# Altium schematic sheet 103C8_baseboard.SchDoc(103C8_baseboard)
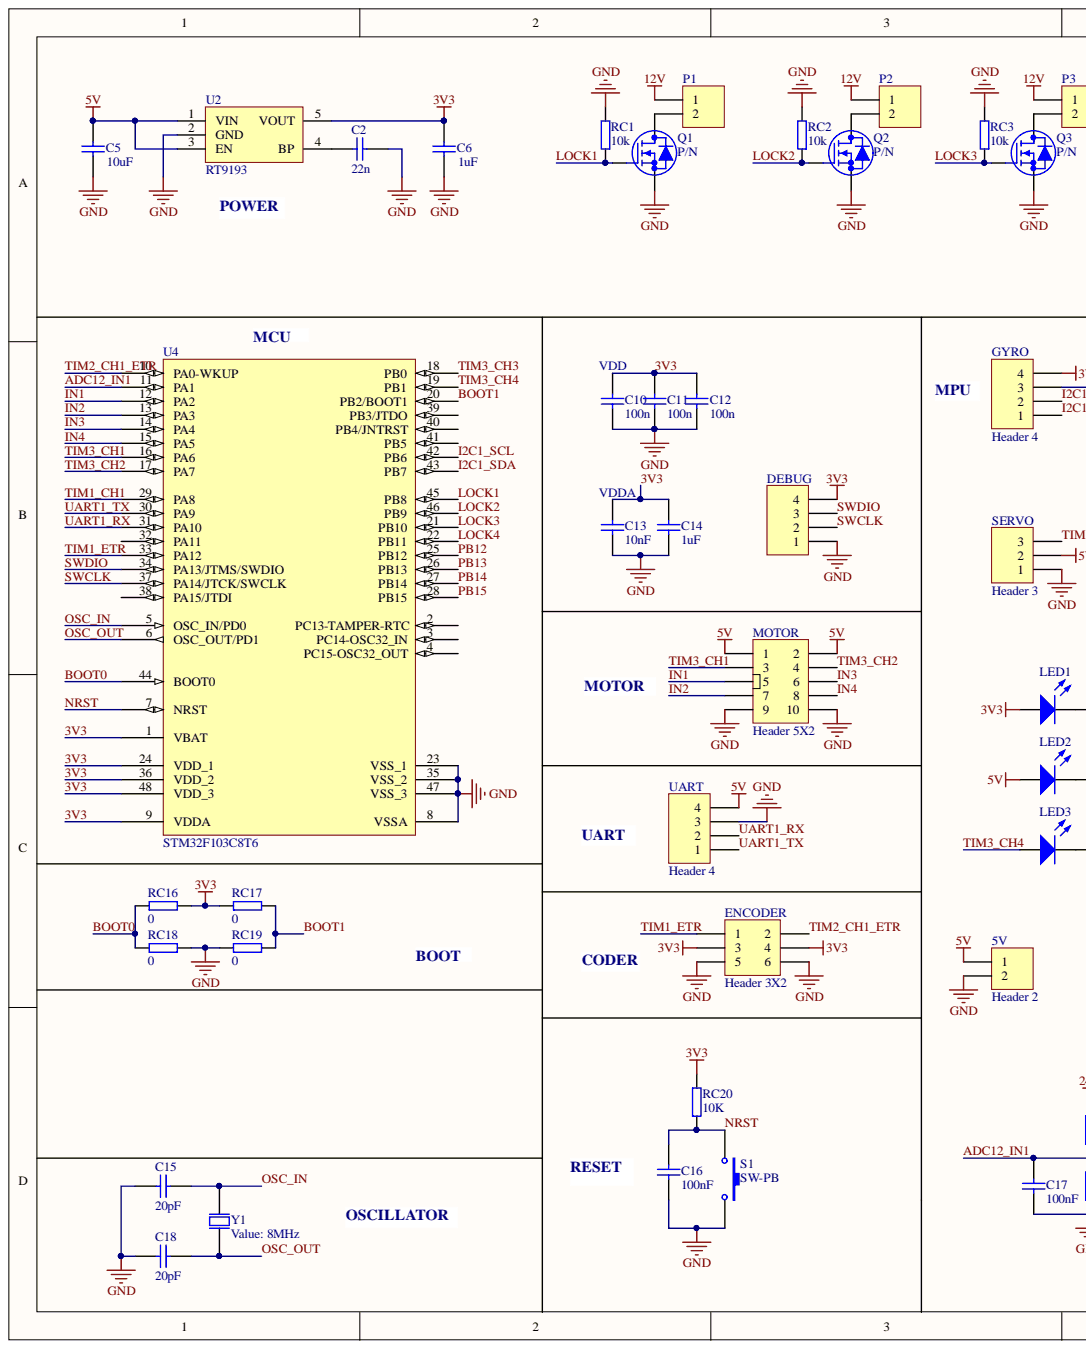
[diagram p0: 103C8_baseboard.SchDoc, left]
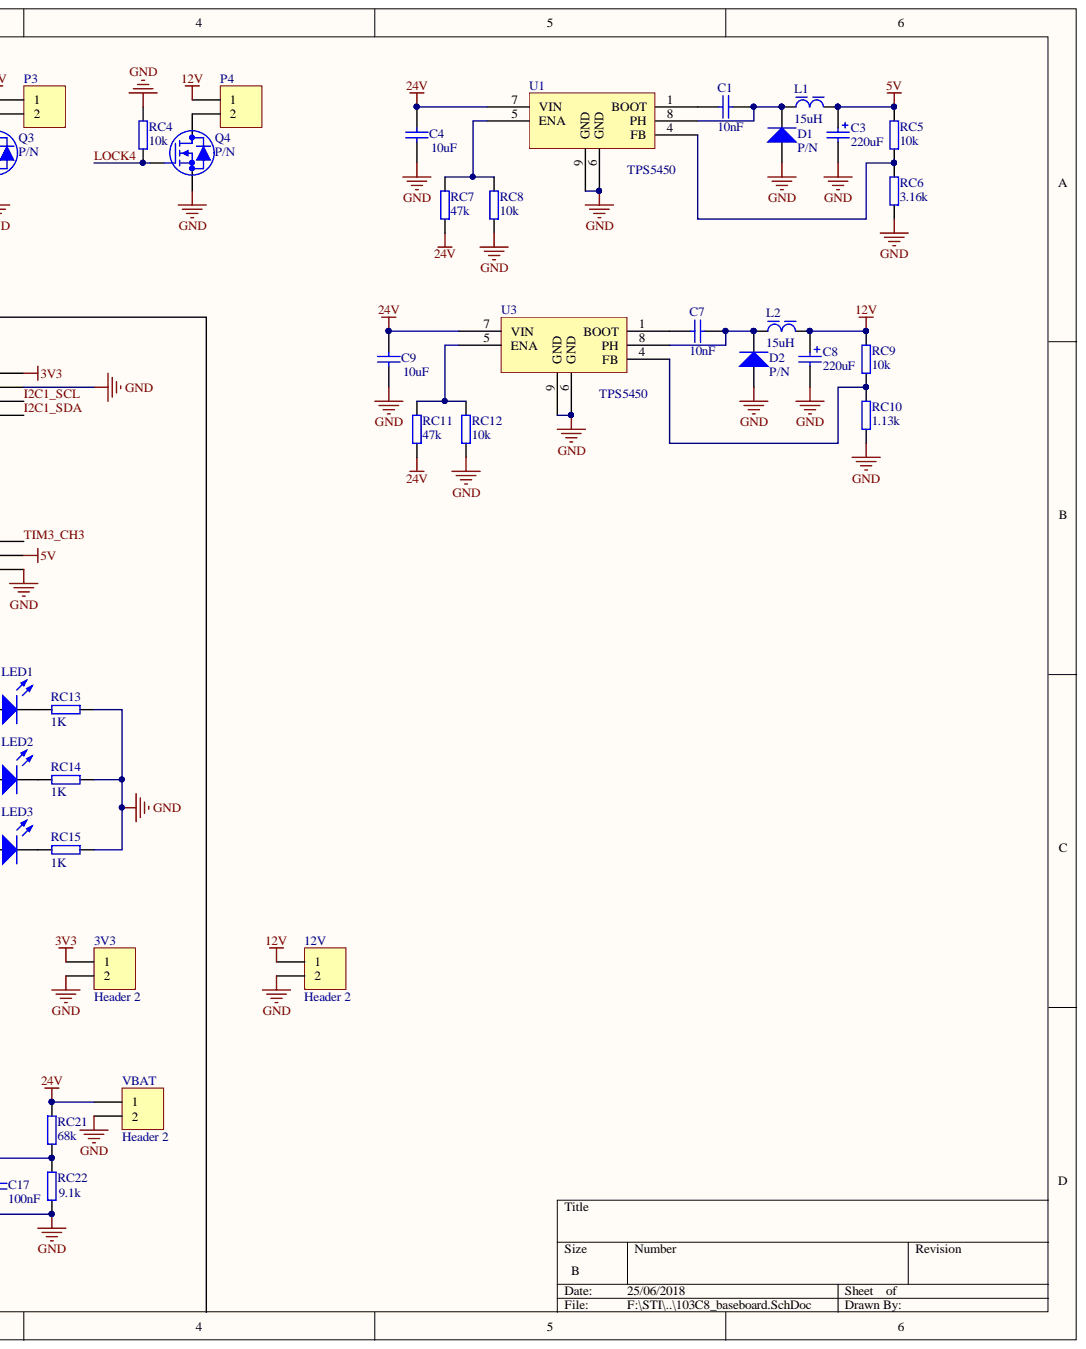
[diagram p1: 103C8_baseboard.SchDoc, right]

## Parts
3V3  Header 2  [HDR1X2]
5V  Header 2  [HDR1X2]
12V  Header 2  [HX2.54-2]
C1  10nF  [CAPC1608[0603]]
C2  22n  [CAPC1608[0603]]
C3  220uF  [CAPPR2-5X5]
C4  10uF  [CAPC1608[0603]]
C5  10uF  [CAPC1608[0603]]
C6  1uF  [CAPC1608[0603]]
C7  10nF  [CAPC1608[0603]]
C8  220uF  [CAPPR2-5X5]
C9  10uF  [CAPC1608[0603]]
C10  100n  [CAPC1608[0603]]
C11  100n  [CAPC1608[0603]]
C12  100n  [CAPC1608[0603]]
C13  10nF  [CAPC1608[0603]]
C14  1uF  [CAPC1608[0603]]
C15  20pF  [CAPC1608[0603]]
C16  100nF  [CAPC1608[0603]]
C17  100nF  [CAPC1608[0603]]
C18  20pF  [CAPC1608[0603]]
D1  P/N  [SMC[DO-214AB]]
D2  P/N  [SMC[DO-214AB]]
DEBUG  (no value)  [HDR1X4]
ENCODER  Header 3X2  [HDR2X3]
GYRO  Header 4  [HDR1X4]
L1  15uH  [SWPA8040S]
L2  15uH  [SWPA8040S]
LED1  Red  [LEDC1608(0603)]  (value not drawn)
LED2  Red  [LEDC1608(0603)]  (value not drawn)
LED3  Red  [LEDC1608(0603)]  (value not drawn)
MOTOR  Header 5X2  [HDR2X5]
P1  Header 2  [HX2.54-2]  (value not drawn)
P2  Header 2  [HX2.54-2]  (value not drawn)
P3  Header 2  [HX2.54-2]  (value not drawn)
P4  Header 2  [HX2.54-2]  (value not drawn)
Q1  P/N  [SOT23]
Q2  P/N  [SOT23]
Q3  P/N  [SOT23]
Q4  P/N  [SOT23]
RC1  10k  [RESC1608[0603]]
RC2  10k  [RESC1608[0603]]
RC3  10k  [RESC1608[0603]]
RC4  10k  [RESC1608[0603]]
RC5  10k  [RESC1608[0603]]
RC6  3.16k  [RESC1608[0603]]
RC7  47k  [RESC1608[0603]]
RC8  10k  [RESC1608[0603]]
RC9  10k  [RESC1608[0603]]
RC10  1.13k  [RESC1608[0603]]
RC11  47k  [RESC1608[0603]]
RC12  10k  [RESC1608[0603]]
RC13  1K  [RESC1608[0603]]
RC14  1K  [RESC1608[0603]]
RC15  1K  [RESC1608[0603]]
RC16  0  [RESC1608[0603]]
RC17  0  [RESC1608[0603]]
RC18  0  [RESC1608[0603]]
RC19  0  [RESC1608[0603]]
RC20  10K  [RESC1608[0603]]
RC21  68k  [RESC1608[0603]]
RC22  9.1k  [RESC1608[0603]]
S1  SW-PB  [KEY_3X4X2]
SERVO  Header 3  [HDR1X3]
U1  TPS5450  [SOIC127P600-8DN]
U2  RT9193  [SOT23-5]
U3  TPS5450  [SOIC127P600-8DN]
U4  STM32F103C8T6  [LQFP48_N]
UART  Header 4  [HDR1X4]
VBAT  Header 2  [HX2.54-2]
Y1  Value: 8MHz  value 8MHz  [SMD2_50X32]

## Nets
5V: 5V.1 C3.1 C5.2 L1.2 LED2.A MOTOR.1 MOTOR.2 RC5.1 SERVO.2 U2.1(VIN) U2.3(EN) UART.4
12V: 12V.1 C8.1 L2.2 P1.1 P2.1 P3.1 P4.1 RC9.1
24V: C4.2 C9.2 RC7.2 RC11.2 RC21.2 U1.7(VIN) U3.7(VIN) VBAT.1
GND: 3V3.2 5V.2 12V.2 C2.1 C3.2 C4.1 C5.1 C6.1 C8.2 C9.1 C10.2 C11.2 C12.2 C13.2 C14.2 C15.2 C16.2 C17.2 C18.2 D1.A D2.A DEBUG.1 ENCODER.5 ENCODER.6 GYRO.3 MOTOR.9 MOTOR.10 Q1.3 Q2.3 Q3.3 Q4.3 RC1.1 RC2.1 RC3.1 RC4.1 RC6.2 RC8.2 RC10.2 RC12.2 RC13.2 RC14.2 RC15.2 RC18.1 RC19.2 RC22.1 S1.2 SERVO.1 U1.6(GND) U1.9(GND) U2.2(GND) U3.6(GND) U3.9(GND) U4.8(VSSA) U4.23(VSS_1) U4.35(VSS_2) U4.47(VSS_3) UART.3 VBAT.2
3V3: 3V3.1 C6.2 C10.1 C11.1 C12.1 C13.1 C14.1 DEBUG.4 ENCODER.3 ENCODER.4 GYRO.4 LED1.A RC16.1 RC17.2 RC20.2 U2.5(VOUT) U4.1(VBAT) U4.9(VDDA) U4.24(VDD_1) U4.36(VDD_2) U4.48(VDD_3)
ADC12_IN1: C17.1 RC21.1 RC22.2 U4.11(PA1)
BOOT0: RC16.2 RC18.2 U4.44(BOOT0)
BOOT1: RC17.1 RC19.1 U4.20(PB2/BOOT1)
I2C1_SCL: GYRO.2 U4.42(PB6)
I2C1_SDA: GYRO.1 U4.43(PB7)
IN1: MOTOR.5 U4.12(PA2)
IN2: MOTOR.7 U4.13(PA3)
IN3: MOTOR.6 U4.14(PA4)
IN4: MOTOR.8 U4.15(PA5)
LOCK1: Q1.1 RC1.2 U4.45(PB8)
LOCK2: Q2.1 RC2.2 U4.46(PB9)
LOCK3: Q3.1 RC3.2 U4.21(PB10)
LOCK4: Q4.1 RC4.2 U4.22(PB11)
NRST: C16.1 RC20.1 S1.1 U4.7(NRST)
OSC_IN: C15.1 U4.5(OSC_IN/PD0) Y1.1
OSC_OUT: C18.1 U4.6(OSC_OUT/PD1) Y1.2
PB12: U4.25(PB12)
PB13: U4.26(PB13)
PB14: U4.27(PB14)
PB15: U4.28(PB15)
SWCLK: DEBUG.2 U4.37(PA14/JTCK/SWCLK)
SWDIO: DEBUG.3 U4.34(PA13/JTMS/SWDIO)
TIM1_CH1: U4.29(PA8)
TIM1_ETR: ENCODER.1 U4.33(PA12)
TIM2_CH1_ETR: ENCODER.2 U4.10(PA0-WKUP)
TIM3_CH1: MOTOR.3 U4.16(PA6)
TIM3_CH2: MOTOR.4 U4.17(PA7)
TIM3_CH3: SERVO.3 U4.18(PB0)
TIM3_CH4: LED3.A U4.19(PB1)
UART1_RX: U4.31(PA10) UART.2
UART1_TX: U4.30(PA9) UART.1
NetC1_1: C1.1 D1.K L1.1 U1.8(PH)
NetC1_2: C1.2 U1.1(BOOT)
NetC2_2: C2.2 U2.4(BP)
NetC7_1: C7.1 D2.K L2.1 U3.8(PH)
NetC7_2: C7.2 U3.1(BOOT)
NetLED1_C: LED1.C RC13.1
NetLED2_C: LED2.C RC14.1
NetLED3_C: LED3.C RC15.1
NetP1_2: P1.2 Q1.2
NetP2_2: P2.2 Q2.2
NetP3_2: P3.2 Q3.2
NetP4_2: P4.2 Q4.2
NetRC5_2: RC5.2 RC6.1 U1.4(FB)
NetRC7_1: RC7.1 RC8.1 U1.5(ENA)
NetRC9_2: RC9.2 RC10.1 U3.4(FB)
NetRC11_1: RC11.1 RC12.1 U3.5(ENA)

Not connected: U4 2(PC13-TAMPER-RTC) 3(PC14-OSC32_IN) 4(PC15-OSC32_OUT) 32(PA11) 38(PA15/JTDI) 39(PB3/JTDO) 40(PB4/JNTRST) 41(PB5)

## Library data of the parts
3V3 5V 12V P1-P4 VBAT = Header 2: Header, 2-Pin; Signal Integrity: Connector; Price(RMB): 0.00; Library Name: Miscellaneous Integrated Library.IntLib; LatestRevisionNote: Re-released for DXP Platform.; LatestRevisionDate: 17-Jul-2002; Component Kind: Standard; Publisher: Altium Limited
C1 C2 C4-C7 C9-C18 = Cap Chip 1: Chip Capacitor, PSpice Model; Voltage: 63V; Tolerance: Y5V; Simulation: CPSPICE; Revision: July-2002: Re-released for DXP Platform.; Published: 8-Jun-2000; Price(RMB): 0.000; Library Name: Miscellaneous Integrated Library.IntLib; Component Kind: Standard; Publisher: Altium Limited
  C1 C7 C13: Value: 10nF
  C2: Value: 22n
  C4 C5 C9: Value: 10uF
  C6 C14: Value: 1uF
  C10-C12: Value: 100n
  C15 C18: Value: 20pF
  C16 C17: Value: 100nF
C3 C8 = Cap Pol 2-5: Polarized Capacitor (Radial); Voltage: 16V; Value: 220uF; Revision: July-2002: Re-released for DXP Platform.; Published: 8-Jun-2000; Price(RMB): 0.000; Library Name: Miscellaneous Integrated Library.IntLib; Component Kind: Standard; Publisher: Altium Limited
D1 D2 = Diode SMx: Molded Diode; Imax: 500mA; Revision: July-2002: Re-released for DXP Platform.; Published: 8-Jun-2000; Price(RMB): 0.000; PartNumber: P/N; Library Name: Miscellaneous Integrated Library.IntLib; Component Kind: Standard; Publisher: Altium Limited
DEBUG GYRO UART = Header 4: Header, 4-Pin; Signal Integrity: Connector; Price(RMB): 0.00; Library Name: Miscellaneous Integrated Library.IntLib; LatestRevisionNote: Re-released for DXP Platform.; LatestRevisionDate: 17-Jul-2002; Component Kind: Standard; Publisher: Altium Limited
ENCODER = Header 3X2: Header, 3-Pin, Dual row; Signal Integrity: Connector; Price(RMB): 0.00; Library Name: Miscellaneous Integrated Library.IntLib; LatestRevisionNote: Re-released for DXP Platform.; LatestRevisionDate: 17-Jul-2002; Component Kind: Standard; Publisher: Altium Limited
L1 L2 = Ind Chip 1: Chip Inductor, Ferrite-Core; Imax: 50mA; DCR: .1m; Value: 15uH; Simulation: INDPSPICE; Revision: July-2002: Re-released for DXP Platform.; Published: 8-Jun-2000; Price(RMB): 0.000; Library Name: Miscellaneous Integrated Library.IntLib; Component Kind: Standard; Publisher: Altium Limited
LED1-LED3 = LED Chip: Typical Chip LED; Revision: July-2002: Re-released for DXP Platform.; Published: 8-Jun-2000; Price(RMB): 0.00; Library Name: Miscellaneous Integrated Library.IntLib; Component Kind: Standard; Color: Red; Publisher: Altium Limited
MOTOR = Header 5X2: Header, 5-Pin, Dual row; Signal Integrity: Connector; Price(RMB): 0.00; Library Name: Miscellaneous Integrated Library.IntLib; LatestRevisionNote: Re-released for DXP Platform.; LatestRevisionDate: 17-Jul-2002; Component Kind: Standard; Publisher: Altium Limited
Q1-Q4 = MOSFET-N: N-Channel MOSFET; Revision: July-2002: Re-released for DXP Platform.; Published: 8-Jun-2000; Price(RMB): 0.000; PartNumber: P/N; Library Name: Miscellaneous Integrated Library.IntLib; Component Kind: Standard; Publisher: Altium Limited
RC1-RC15 RC20-RC22 = Res Chip 2p 1: Chip Resistor, PSpice Model, IPC PCB Library Drawing; Tolerance: 5%; TC2: 0; TC1: 0; Simulation: RPSPICE; Revision: July-2002: Re-released for DXP Platform.; Published: 8-Jun-2000; Price(RMB): 0.000; Library Name: Miscellaneous Integrated Library.IntLib; Component Kind: Standard; Publisher: Altium Limited
  RC1-RC5 RC8 RC9 RC12: Value: 10k
  RC6: Value: 3.16k
  RC7 RC11: Value: 47k
  RC10: Value: 1.13k
  RC13-RC15: Value: 1K
  RC20: Value: 10K
  RC21: Value: 68k
  RC22: Value: 9.1k
RC16-RC19 = Res Chip 2p 1: Chip Resistor, PSpice Model, IPC PCB Library Drawing; Tolerance: 5%; Value: 0; TC2: 0; TC1: 0; Simulation: RPSPICE; Revision: July-2002: Re-released for DXP Platform.; Published: 8-Jun-2000; Price(RMB): 0.000; Library Name: Miscellaneous Integrated Library.IntLib; Component Kind: Standard; Publisher: Altium Limited
S1 = SW-PB: Switch; Published: 24-Mar-1999; PackageReference: SPST-2; PackageDescription: Switch; 2 Leads; Library Name: Miscellaneous Devices.IntLib; LatestRevisionNote: Re-released for DXP Platform.; LatestRevisionDate: 17-Jul-2002; Component Kind: Standard; Publisher: Altium Limited
SERVO = Header 3: Header, 3-Pin; Signal Integrity: Connector; Price(RMB): 0.00; Library Name: Miscellaneous Integrated Library.IntLib; LatestRevisionNote: Re-released for DXP Platform.; LatestRevisionDate: 17-Jul-2002; Component Kind: Standard; Publisher: Altium Limited
U4 = STM32F103C8T6: STM32 ARM-based 32-bit MCU with 64 Kbytes Flash, 48-pin LQFP, Industrial Temperature; Published: 30-May-2008; PackageVersion: Mar-2008; PackageReference: LQFP48; PackageDescription: 48-Pin Low-Profile Quad Flat Pack, 7 x 7 mm Body, 0.5 mm Pitch (LQFP48); Library Name: ST Microcontroller 32-Bit STM32.IntLib; DatasheetVersion: rev6, Mar-2008; ComponentLink2URL: http://www.st.com/stonline/products/literature/ds/13587.pdf; ComponentLink2Description: Datasheet; ComponentLink1URL: www.st.com/stm32; ComponentLink1Description: Manufacturer Link; Component Kind: Standard; Publisher: Altium Limited
Y1 = XTAL: Crystal Oscillator; Revision: July-2002: Re-released for DXP Platform.; Published: 8-Jun-2000; Price(RMB): 0.000; Library Name: Miscellaneous Integrated Library.IntLib; Component Kind: Standard; Publisher: Altium Limited
U1 U3 = TPS5450: Component Kind: Standard; Library Name: LIQI.SchLib
U2 = RT9193: Component Kind: Standard; Library Name: LIQI.SchLib
